# Altium schematic sheet ARM.SchDoc(ARM)
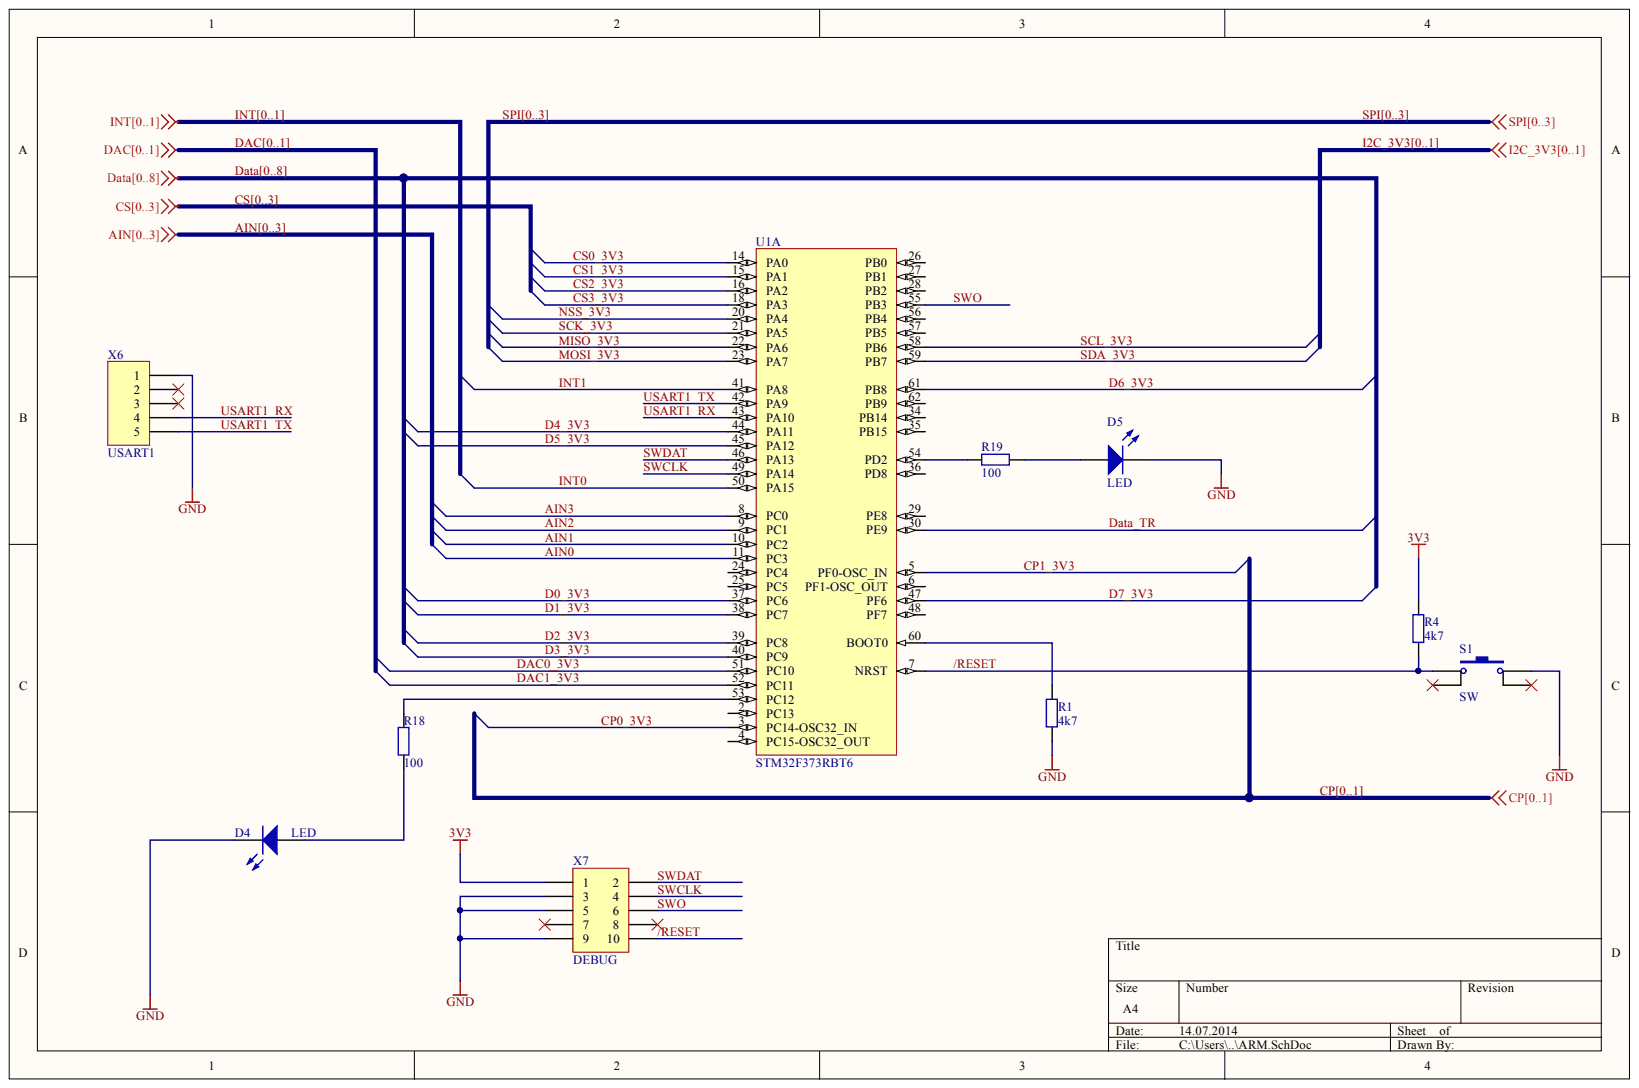
[diagram p0: ARM.SchDoc]

## Parts
D4  LED  [D_0603]
D5  LED  [D_0603]
R1  R  value 4k7  [R_0603]
R4  R  value 4k7  [R_0603]
R18  R  value 100  [R_0603]
R19  R  value 100  [R_0603]
S1  SW  [SW_4x4x1.5]
U1  STM32F373RBT6  [STM-LQFP64_V]  (part A)
X6  USART1  [HDR1X5H]
X7  DEBUG  [HDR2X5H]

## Nets
3V3: R4.2 X7.1
GND: D4.2 D5.2 R1.1 S1.2 X6.1 X7.3 X7.5 X7.9
/RESET: R4.1 S1.1 U1.7(NRST) X7.10
AIN0: U1.11(PC3)  [also labelled AIN]
AIN1: U1.10(PC2)  [also labelled AIN]
AIN2: U1.9(PC1)  [also labelled AIN]
AIN3: U1.8(PC0)  [also labelled AIN]
CP0_3V3: U1.3(PC14-OSC32_IN)
CP1_3V3: U1.5(PF0-OSC_IN)
CS0_3V3: U1.14(PA0)
CS1_3V3: U1.15(PA1)
CS2_3V3: U1.16(PA2)
CS3_3V3: U1.18(PA3)
D0_3V3: U1.37(PC6)
D1_3V3: U1.38(PC7)
D2_3V3: U1.39(PC8)
D3_3V3: U1.40(PC9)
D4_3V3: U1.44(PA11)
D5_3V3: U1.45(PA12)
D6_3V3: U1.61(PB8)
D7_3V3: U1.47(PF6)
DAC0_3V3: U1.51(PC10)
DAC1_3V3: U1.52(PC11)
DATA_TR: U1.30(PE9)
INT0: U1.50(PA15)  [also labelled INT]
INT1: U1.41(PA8)  [also labelled INT]
MISO_3V3: U1.22(PA6)
MOSI_3V3: U1.23(PA7)
NSS_3V3: U1.20(PA4)
SCK_3V3: U1.21(PA5)
SCL_3V3: U1.58(PB6)
SDA_3V3: U1.59(PB7)
SWCLK: U1.49(PA14) X7.4
SWDAT: U1.46(PA13) X7.2
SWO: U1.55(PB3) X7.6
USART1_RX: U1.43(PA10) X6.4
USART1_TX: U1.42(PA9) X6.5
NetD4_1: D4.1 R18.1
NetD5_1: D5.1 R19.1
NetR1_2: R1.2 U1.60(BOOT0)
NetR18_2: R18.2 U1.53(PC12)
NetR19_2: R19.2 U1.54(PD2)
CP0:  [also labelled CP]
CP1:  [also labelled CP]
CS0:  [also labelled CS]
CS1:  [also labelled CS]
CS2:  [also labelled CS]
CS3:  [also labelled CS]
DAC0:  [also labelled DAC]
DAC1:  [also labelled DAC]
DATA0:  [also labelled DATA]
DATA1:  [also labelled DATA]
DATA2:  [also labelled DATA]
DATA3:  [also labelled DATA]
DATA4:  [also labelled DATA]
DATA5:  [also labelled DATA]
DATA6:  [also labelled DATA]
DATA7:  [also labelled DATA]
DATA8:  [also labelled DATA]
I2C_3V3[0..1]:
I2C_3V30:
I2C_3V31:
SPI0:  [also labelled SPI]
SPI1:  [also labelled SPI]
SPI2:  [also labelled SPI]
SPI3:  [also labelled SPI]

Not connected: S1 3 4; U1 2(PC13) 4(PC15-OSC32_OUT) 6(PF1-OSC_OUT) 24(PC4) 25(PC5) 26(PB0) 27(PB1) 28(PB2) 29(PE8) 34(PB14) 35(PB15) 36(PD8) 48(PF7) 56(PB4) 57(PB5) 62(PB9); X6 2 3; X7 7 8

## Library data of the parts
R1 R4 R18 R19 = RES_EU: Component Kind: Standard; Library Name: Schlib1.SchLib
U1 = CMP-0572-00032-2: ARM Cortex-M4 32-bit MCU, 128 KB Flash, 24 KB Internal RAM, 52 I/Os, 64-pin LQFP, -40 to 85 degC, Tray; FLASH Size (Prog) (kB): 128; I/Os (High Current): 52; Internal RAM Size (kB): 24; Operating Frequency(F) (Processor speed) (MHz): 72; Supply Voltage(Vcc) min (V): 2; Component Kind: Standard; ComponentLink1Description: Manufacturer URL; ComponentLink1URL: http://www.st.com/web/en/home.html; ComponentLink2Description: Datasheet; ComponentLink2URL: http://www.st.com/st-web-ui/static/active/en/resource/technical/document/datasheet/DM00046749.pdf; ComponentLink3Description: Package Specification; ComponentLink3URL: http://www.st.com/st-web-ui/static/active/en/resource/technical/document/datasheet/DM00037051.pdf; Core: ARM Cortex-M4; DatasheetVersion: Rev. 3; Library Name: Altium Content Vault; Mounting Technology: Surface Mount; PackageDescription: 64-Pin Low Profile Quad Flat Package, 10 x 10 mm Body, 0.5 mm Pitch; PackageReference: LQFP64; PackageVersion: Rev. 4, 06/2013; Packing: Tray; PartNumber: STM32F373RBT6
X6 = Header 5H: Header, 5-Pin, Right Angle; Component Kind: Standard; LatestRevisionDate: 17-Jul-2002; LatestRevisionNote: Re-released for DXP Platform.; Library Name: Miscellaneous Connectors.IntLib; Signal Integrity: Connector; Publisher: Altium Limited
X7 = Header 5X2H: Header, 5-Pin, Dual row, Right Angle; Component Kind: Standard; LatestRevisionDate: 17-Jul-2002; LatestRevisionNote: Re-released for DXP Platform.; Library Name: Miscellaneous Connectors.IntLib; Signal Integrity: Connector; Publisher: Altium Limited
D4 D5 = LED: Component Kind: Standard; Library Name: Schlib1.SchLib
S1 = SW: Component Kind: Standard; Library Name: Schlib1.SchLib
